# Altium schematic sheet Sheet1.SchDoc(Sheet1)
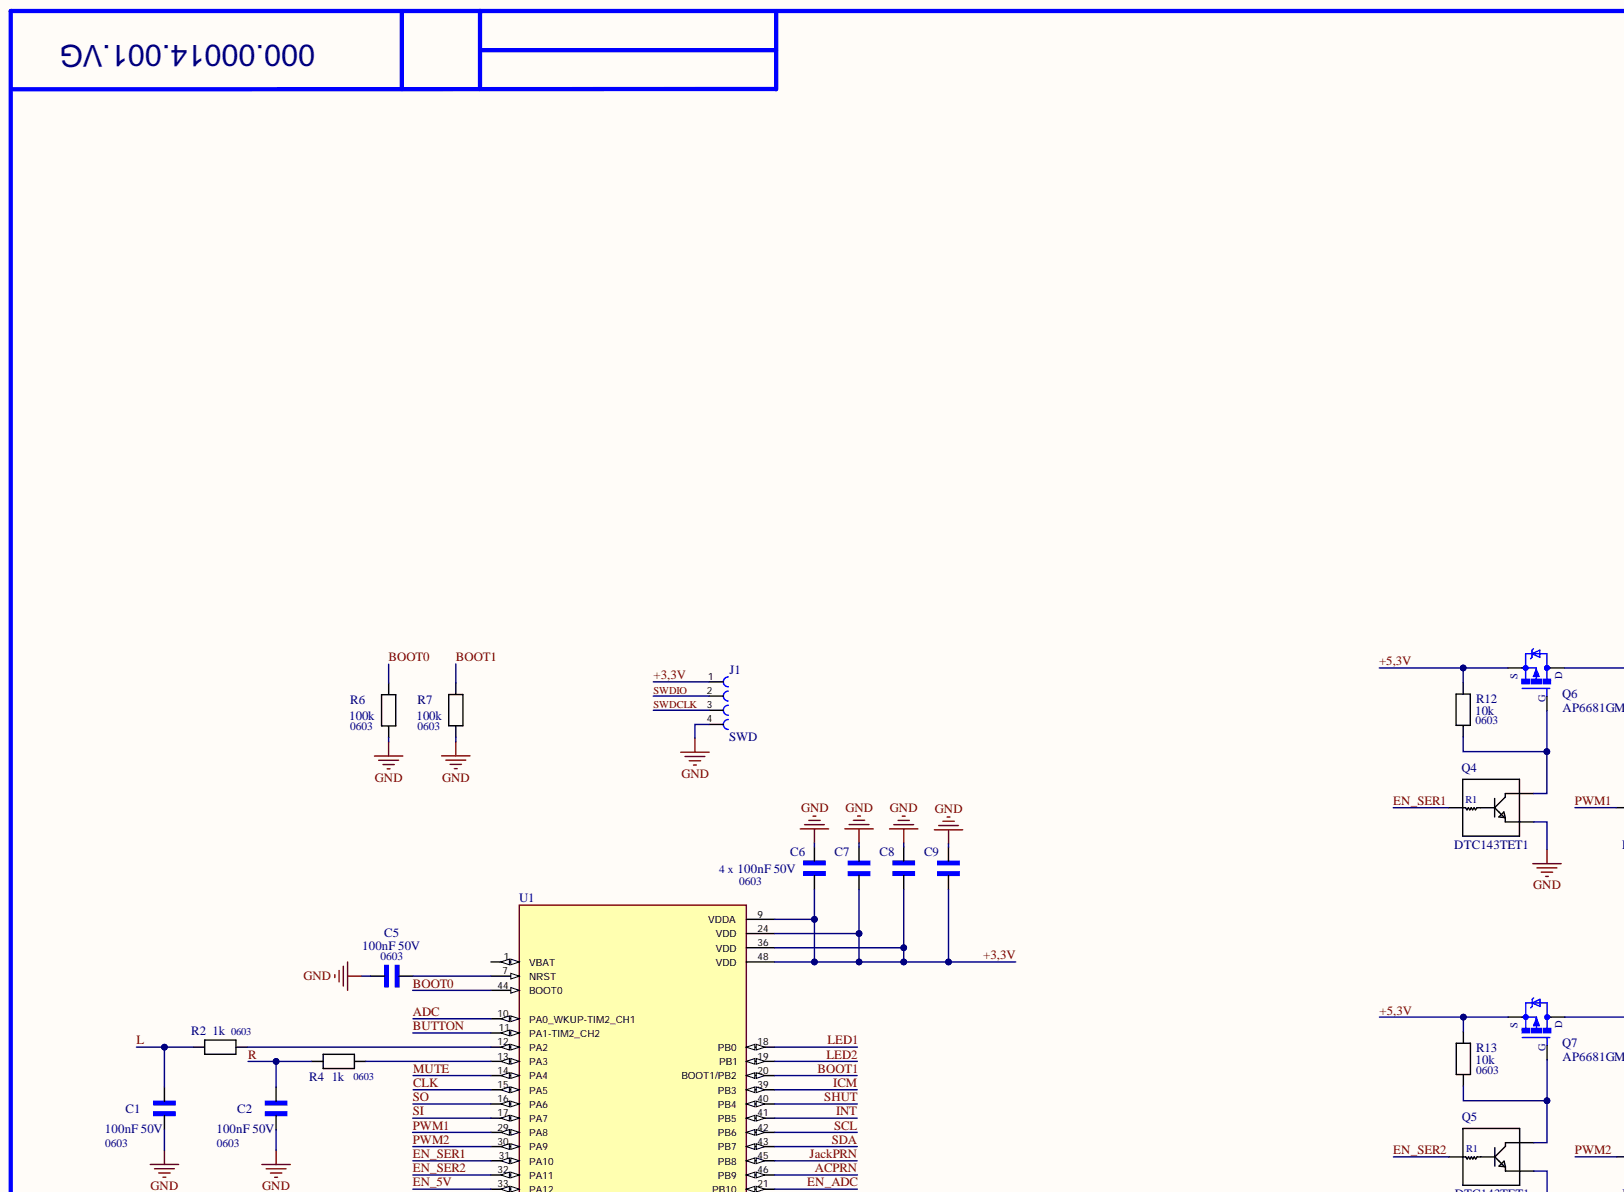
[diagram p0: Sheet1.SchDoc, top left]
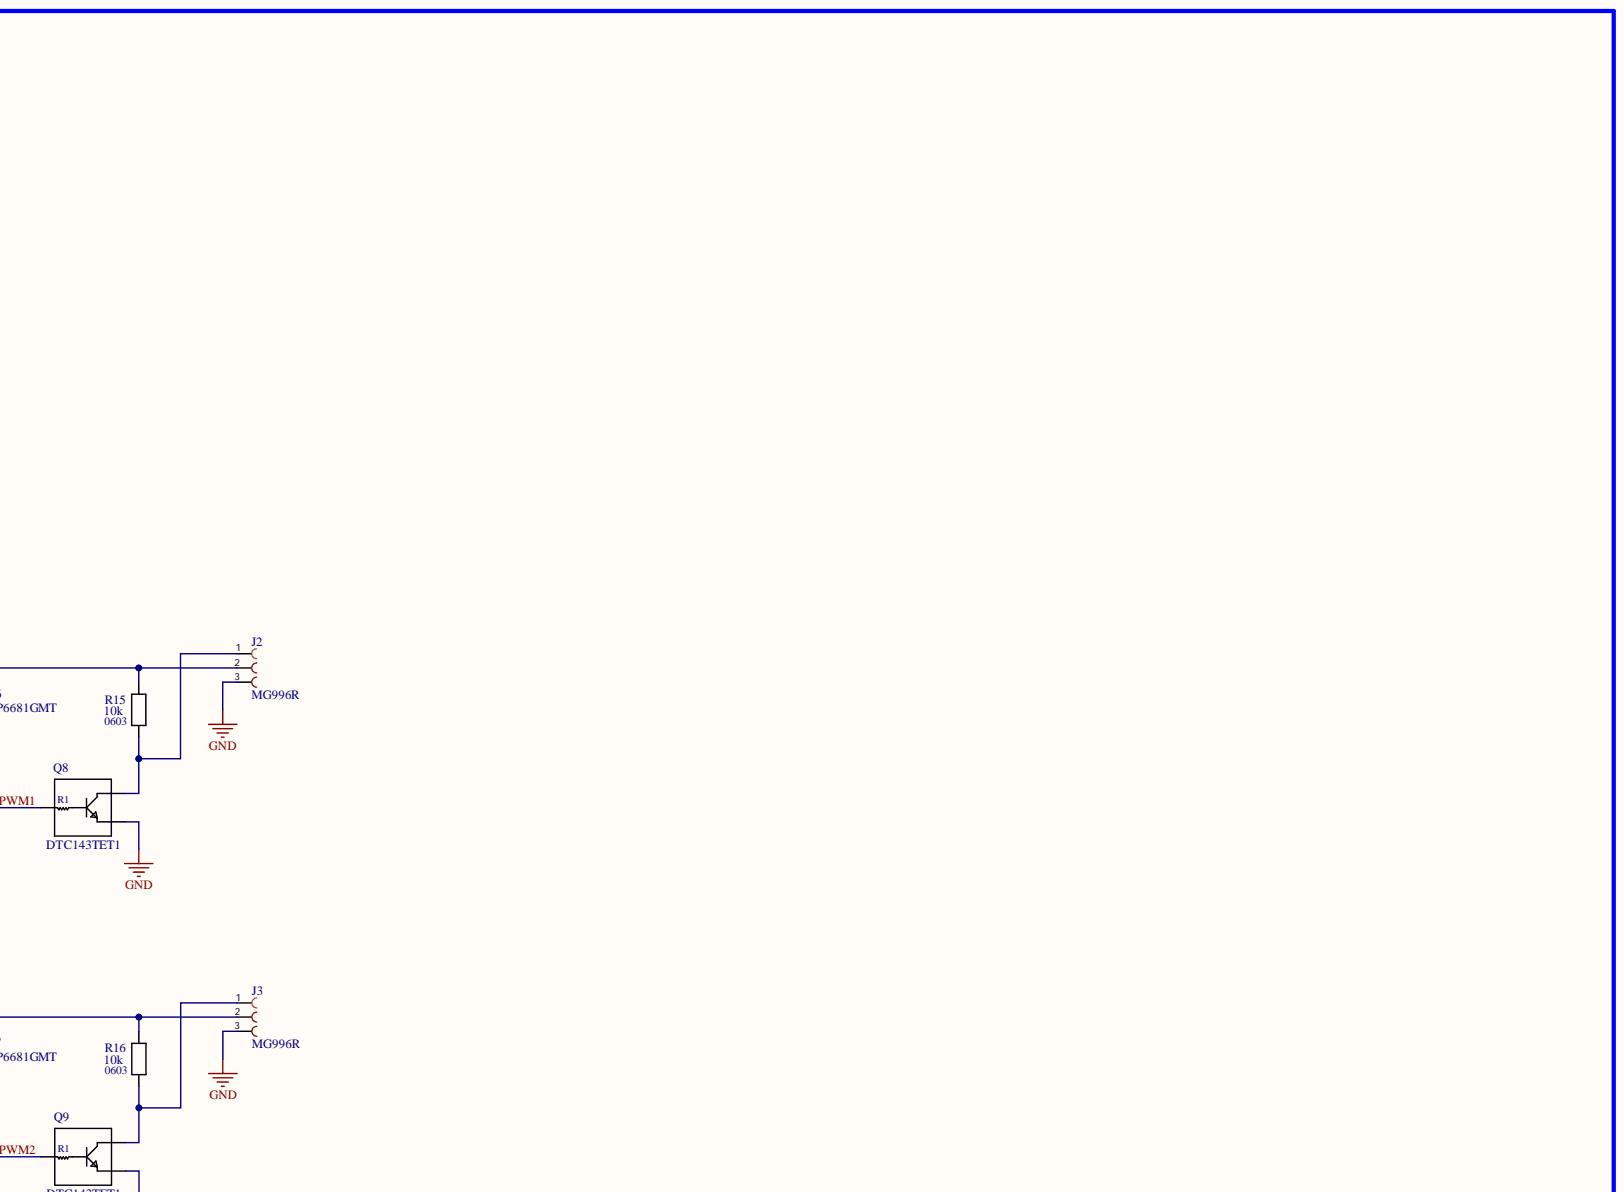
[diagram p1: Sheet1.SchDoc, top right]
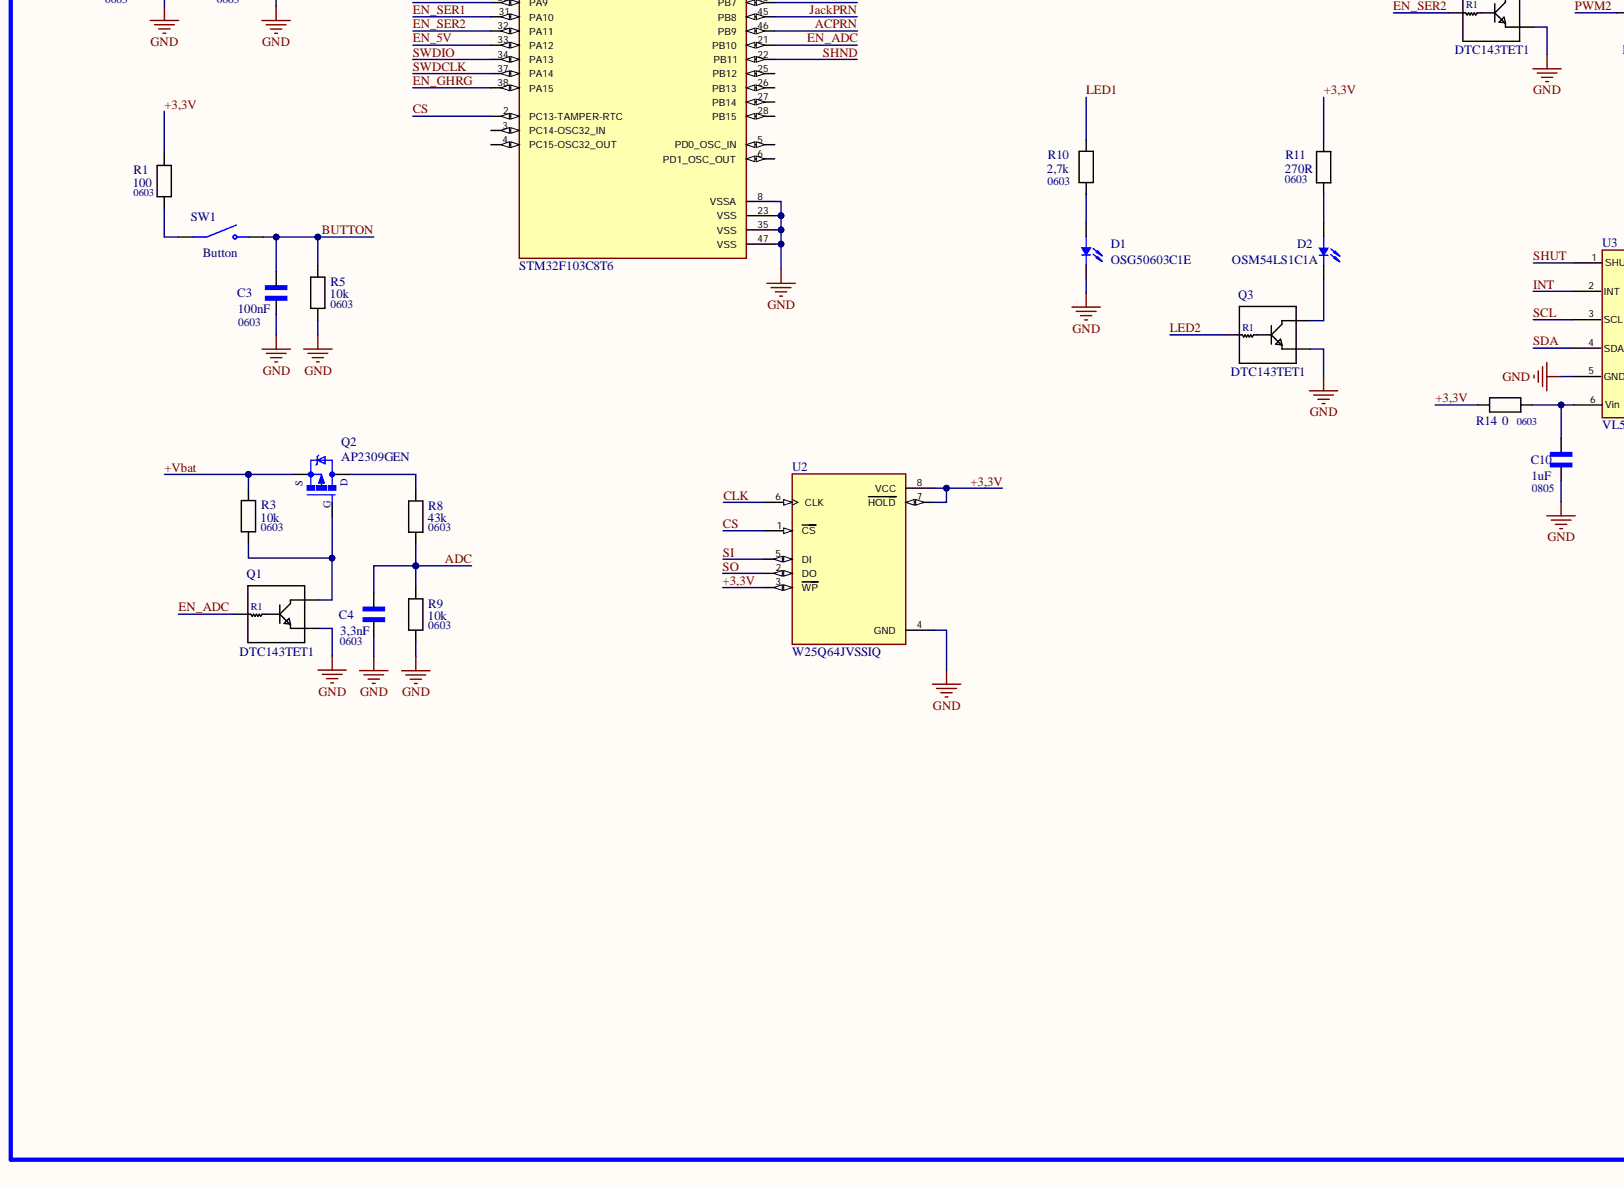
[diagram p2: Sheet1.SchDoc, bottom left]
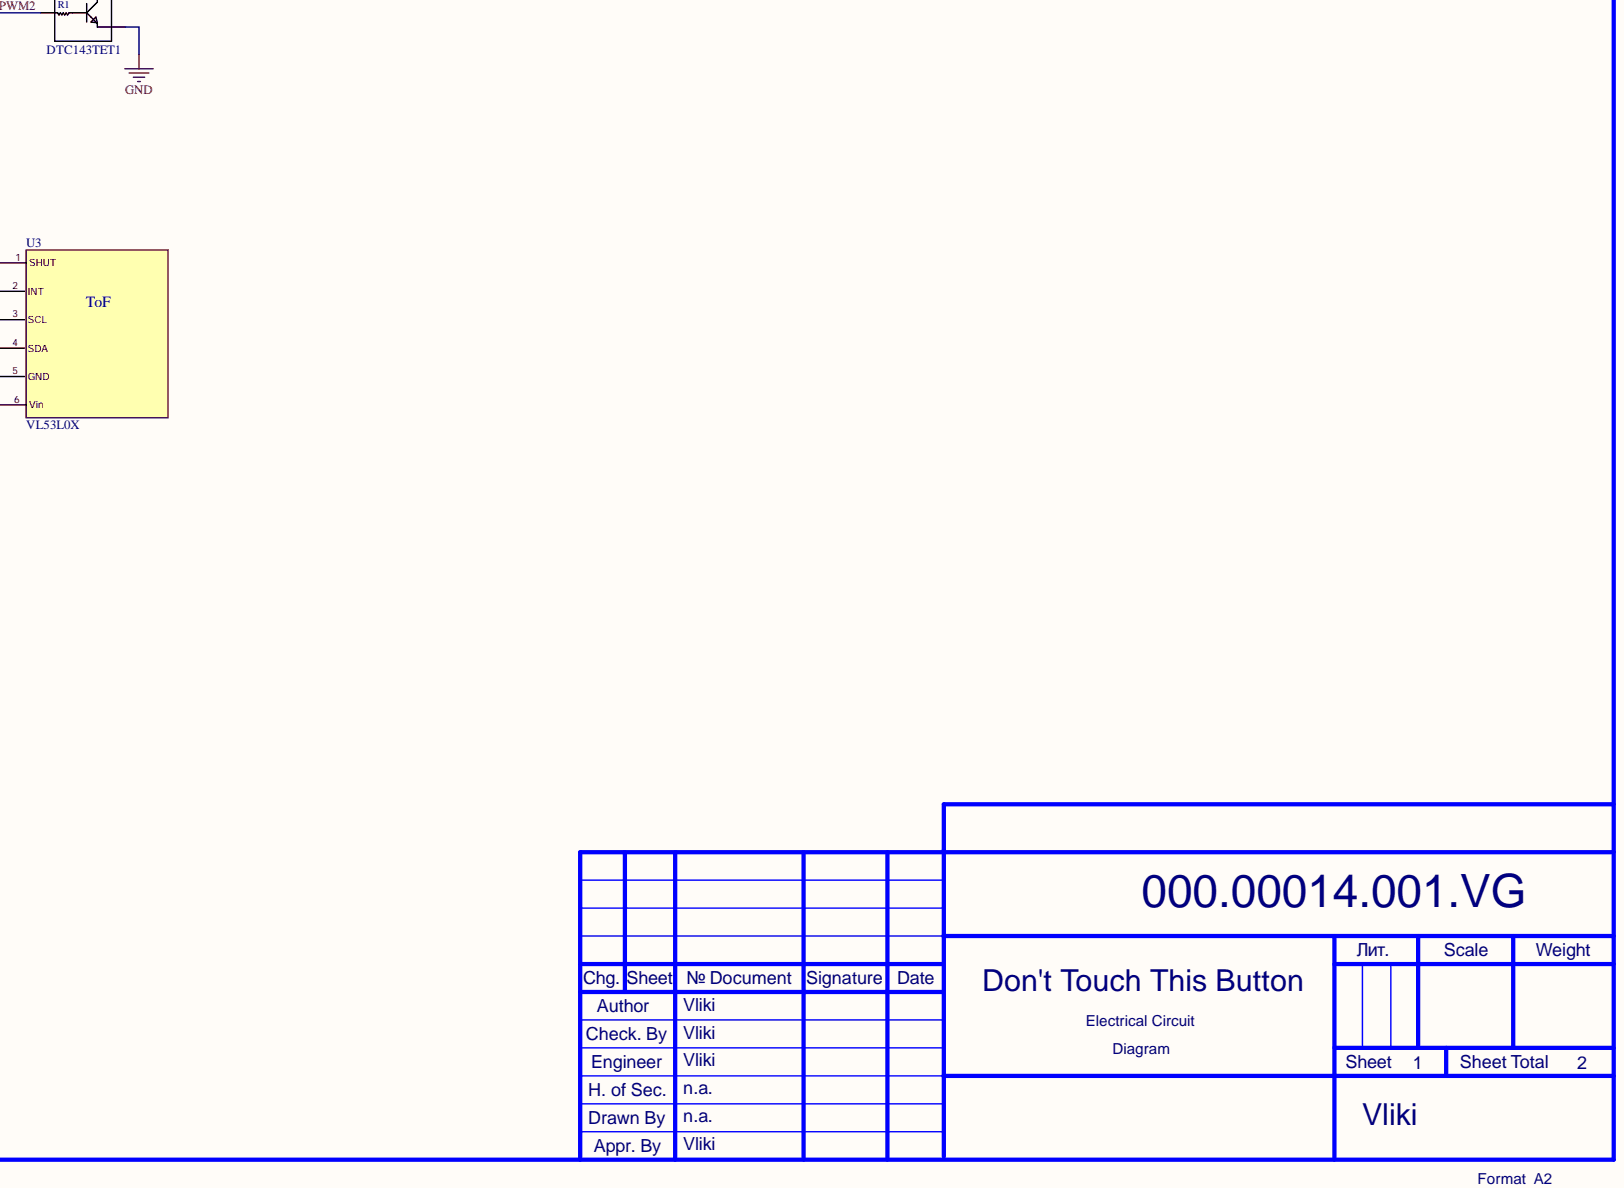
[diagram p3: Sheet1.SchDoc, bottom right]

## Parts
C1  100nF 50V  Case: 0603  [SMD 0603 CAP]
C2  100nF 50V  Case: 0603  [SMD 0603 CAP]
C3  100nF  Case: 0603  [SMD 0603 CAP]
C4  3,3nF  Case: 0603  [SMD 0603 CAP]
C5  100nF 50V  Case: 0603  [SMD 0603 CAP]
C6  100nF 50V  [SMD 0603 CAP]
C7  100nF 50V  [SMD 0603 CAP]
C8  100nF 50V  [SMD 0603 CAP]
C9  100nF 50V  [SMD 0603 CAP]
C10  1uF  Case: 0805  [SMD 0805 CAP]
D1  OSG50603C1E  [SMD 0805 LED-G]
D2  OSM54LS1C1A  [LED-3mm Y]
J1  SWD  [PN1X4]
J2  MG996R  [PN1X3]
J3  MG996R  [PN1X3]
Q1  DTC143TET1  [SC75]
Q2  AP2309GEN  [SOT-23-3]
Q3  DTC143TET1  [SC75]
Q4  DTC143TET1  [SC75]
Q5  DTC143TET1  [SC75]
Q6  AP6681GMT  [PMPAK 5x6]
Q7  AP6681GMT  [PMPAK 5x6]
Q8  DTC143TET1  [SC75]
Q9  DTC143TET1  [SC75]
R1  100  Case: 0603  [SMD 0603]
R2  1k  Case: 0603  [SMD 0603]
R3  10k  Case: 0603  [SMD 0603]
R4  1k  Case: 0603  [SMD 0603]
R5  10k  Case: 0603  [SMD 0603]
R6  100k  Case: 0603  [SMD 0603]
R7  100k  Case: 0603  [SMD 0603]
R8  43k  Case: 0603  [SMD 0603]
R9  10k  Case: 0603  [SMD 0603]
R10  2,7k  Case: 0603  [SMD 0603]
R11  270R  Case: 0603  [SMD 0603]
R12  10k  Case: 0603  [SMD 0603]
R13  10k  Case: 0603  [SMD 0603]
R14  0  Case: 0603  [SMD 0603]
R15  10k  Case: 0603  [SMD 0603]
R16  10k  Case: 0603  [SMD 0603]
SW1  Button  [PN1X2]
U1  STM32F103C8T6  [QFP50P900X900X160-48N]
U2  W25Q64JVSSIQ  [SO8 5,3mm]
U3  VL53L0X  [PN1X6]

## Nets
GND: C1.1 C2.1 C3.1 C4.1 C5.1 C6.2 C7.2 C8.2 C9.2 C10.1 D1.C J1.4 J2.3 J3.3 Q1.2 Q3.2 Q4.2 Q5.2 Q8.2 Q9.2 R5.1 R6.2 R7.2 R9.1 U1.8(VSSA) U1.23(VSS) U1.35(VSS) U1.47(VSS) U2.4(GND) U3.5(GND)
+3,3V: C6.1 C7.1 C8.1 C9.1 J1.1 R1.1 R11.1 R14.2 U1.9(VDDA) U1.24(VDD) U1.36(VDD) U1.48(VDD) U2.3(WP) U2.7(HOLD) U2.8(VCC)
+5,3V: Q6.2 Q7.2 R12.2 R13.2
+Vbat: Q2.2 R3.2
ACPRN: U1.46(PB9)
ADC: C4.2 R8.1 R9.2 U1.10(PA0_WKUP-TIM2_CH1)
BOOT0: R6.1 U1.44(BOOT0)
BOOT1: R7.1 U1.20(BOOT1/PB2)
BUTTON: C3.2 R5.2 SW1.2 U1.11(PA1-TIM2_CH2)
CLK: U1.15(PA5) U2.6(CLK)
CS: U1.2(PC13-TAMPER-RTC) U2.1(CS)
EN_5V: U1.33(PA12)
EN_ADC: Q1.1 U1.21(PB10)
EN_GHRG: U1.38(PA15)
EN_SER1: Q4.1 U1.31(PA10)
EN_SER2: Q5.1 U1.32(PA11)
ICM: U1.39(PB3)
INT: U1.41(PB5) U3.2(INT)
JackPRN: U1.45(PB8)
L: C1.2 R2.2
LED1: R10.2 U1.18(PB0)
LED2: Q3.1 U1.19(PB1)
MUTE: U1.14(PA4)
PWM1: Q8.1 U1.29(PA8)
PWM2: Q9.1 U1.30(PA9)
R: C2.2 R4.2
SCL: U1.42(PB6) U3.3(SCL)
SDA: U1.43(PB7) U3.4(SDA)
SHND: U1.22(PB11)
SHUT: U1.40(PB4) U3.1(SHUT)
SI: U1.17(PA7) U2.5(DI)
SO: U1.16(PA6) U2.2(DO)
SWDCLK: J1.3 U1.37(PA14)
SWDIO: J1.2 U1.34(PA13)
NetC5_2: C5.2 U1.7(NRST)
NetC10_2: C10.2 R14.1 U3.6(Vin)
NetD1_A: D1.A R10.1
NetD2_A: D2.A R11.2
NetD2_C: D2.C Q3.3
NetJ2_1: J2.1 Q8.3 R15.1
NetJ2_2: J2.2 Q6.3 R15.2
NetJ3_1: J3.1 Q9.3 R16.1
NetJ3_2: J3.2 Q7.3 R16.2
NetQ1_3: Q1.3 Q2.1 R3.1
NetQ2_3: Q2.3 R8.2
NetQ4_3: Q4.3 Q6.1 R12.1
NetQ5_3: Q5.3 Q7.1 R13.1
NetR1_2: R1.2 SW1.1
NetR2_1: R2.1 U1.12(PA2)
NetR4_1: R4.1 U1.13(PA3)

Not connected: U1 1(VBAT) 3(PC14-OSC32_IN) 4(PC15-OSC32_OUT) 5(PD0_OSC_IN) 6(PD1_OSC_OUT) 25(PB12) 26(PB13) 27(PB14) 28(PB15)

## Library data of the parts
C1 C2 C5 C7-C9 = CL32B106KLJNNNE: 100nF 50V X7R +-10%; Manufacturer: SAMSUNG ELECTRO-MECHANICS; Component Kind: Standard; HelpURL: https://store.comet.bg/Catalogue/Product/36844/; Library Name: CAN_Library.IntLib; Model: CL32B106KLJNNNE; Value: 100nF 50V
  C7-C9: Case: 0603
C3 = CL32B106KLJNNNE: 100nF 50V X7R +-10%; Manufacturer: SAMSUNG ELECTRO-MECHANICS; Model: CL10B104KB8NNNC; Component Kind: Standard; HelpURL: https://store.comet.bg/Catalogue/Product/5963/; Library Name: CAN_Library.IntLib; Value: 100nF 50V
C4 = CL32B106KLJNNNE: 2.2uF 16V X7R +-10%; Manufacturer: SAMSUNG ELECTRO-MECHANICS; Model: CL10B332KB8NNNC; Value: 3,3nF 50V; Component Kind: Standard; HelpURL: https://store.comet.bg/Catalogue/Product/5613/; Library Name: CAN_Library.IntLib
C6 = CL32B106KLJNNNE: 100nF 50V X7R +-10%; Manufacturer: SAMSUNG ELECTRO-MECHANICS; Text: 4 x; Case: 0603; Component Kind: Standard; HelpURL: https://store.comet.bg/Catalogue/Product/36844/; Library Name: CAN_Library.IntLib; Model: CL32B106KLJNNNE; Value: 100nF 50V
C10 = CL32B106KLJNNNE: 1.0uF 50V X7R +-10%; Manufacturer: SAMSUNG ELECTRO-MECHANICS; Model: CL21B105KBFNNNE; Value: 1uF 50V; Component Kind: Standard; HelpURL: https://store.comet.bg/Catalogue/Product/9031/; Library Name: CAN_Library.IntLib
D1 = KP-2012: 1.6x0.8mm (0603), 120-220mcd/5mA, Pure Green; MF: KINGBRIGHT; Case: 0805 Rohm Semiconductor; Component Kind: Standard; Library Name: CAN_Library.IntLib; URL: https://store.comet.bg/Catalogue/Product/49228/; Value: OSG50603C1E
D2 = KP-2012: 3.5x2.8mm Warm White 3000K 2500mcd 6lm 120deg; MF: OPTOSUPPLY INTERNATIONAL; Case: 3.2x2.8mm; Component Kind: Standard; Library Name: CAN_Library.IntLib; Value: OSM54LS1C1A
J1 = PN1X4: Single Row / Straight / Without Shroud Female header Female 4 @ Pin header, socket _Si...; Value: PN1X4; Case: 0,8 mm; Component Kind: Standard; Library Name: CAN_Library.IntLib
J2 J3 = MG996R: Servo 4.8V - 7.2V, 0.9 - 2.5A, 0 ºC – 55 ºC, 55g, PWM 20mS; Case: PN1X3; Component Kind: Standard; Library Name: CAN_Library.IntLib; Value: MG996R
Q1 Q3-Q5 Q8 Q9 = DTC143TET1: NPN Digital 50V 100mA 200mW  R1=4,7kOm; Manufacturer: ROHM Semiconductor; Case: SC75; Component Kind: Standard; HalpURL: https://store.comet.bg/Catalogue/Product/5040902/; Library Name: CAN_Library.IntLib; Value: DTC143TET1
Q2 = AP2309GEN: PMOS 30V 4.2A 1.38W 52mOhm/10V; 80mOhm/4.5V; MF: ADVANCED POWER ELECTR. CO; MP: AP2309GEN-HF-3TR; Case: SOT-23-3; Component Kind: Standard; Library Name: CAN_Library.IntLib; URL: https://store.comet.bg/Catalogue/Product/49685/; Value: AP2309GEN
Q6 Q7 = AP2309GEN: PMOS 30V 135A 83.3W 3.1mOhm/10V; 4.6mOhm/4.5V; MF: ADVANCED POWER ELECTR. CO; Case: PMPAK 5X6; Component Kind: Standard; Library Name: CAN_Library.IntLib; URL: https://store.comet.bg/Catalogue/Product/18327/; Value: AP6681GMT
R1 = Resistor_2: RES SMD 0603 5% 200ppm 100R 1/10W; Component Kind: Standard; HelpURL: https://store.comet.bg/Catalogue/Product/3448/; Library Name: CAN_Library.IntLib; Value: 100
R2 R4 = Resistor_2: Описание: RES SMD 0603 5% 200ppm 1.0K 1/10W; Component Kind: Standard; HelpURL: https://store.comet.bg/Catalogue/Product/3458/; Library Name: CAN_Library.IntLib; Value: 1k
R3 R5 R9 R12 R13 R15 R16 = Resistor_2: RES SMD 0603 5% 200ppm 47K 1/4W; Component Kind: Standard; HelpURL: https://store.comet.bg/Catalogue/Product/29639/; Library Name: CAN_Library.IntLib; Value: 10k
R6 R7 R10 R11 = Resistor_2: RES; Component Kind: Standard; HelpURL: https://store.comet.bg/Catalogue/RES/Resistors/#eyJpcHAiOiIxMDAiLCJtIjoiIn0%3D; Library Name: CAN_Library.IntLib
  R6 R7: Value: 100k
  R10: Value: 2,7k
  R11: Value: 270R
R8 = Resistor_2: RES SMD 0603 5% 200ppm 43K 1/10W; Component Kind: Standard; HelpURL: https://store.comet.bg/Catalogue/Product/4841/; Library Name: CAN_Library.IntLib; Value: 43k
R14 = Resistor_2: RES SMD 0603 JUMPER MAX 50mOhm; Component Kind: Standard; HelpURL: https://store.comet.bg/Catalogue/Product/4437/; Library Name: CAN_Library.IntLib; Value: 0
SW1 = Switch: Switch 2pin SPDT ON-ON 21x15mm 6A/250VAC; Value: MRS-101A; Component Kind: Standard; Library Name: CAN_Library.IntLib; Package: None
U1 = STM32F103C8T6: ARMÂ® CortexÂ®-M3 STM32F1 Microcontroller IC 32-Bit 72MHz 64KB (64K x 8) FLASH 48-LQFP (7x7); MF: STMicroelectronics; Availability: In Stock; Check_prices: https://www.snapeda.com/parts/STM32F103C8T6/STMicroelectronics/view-part/?ref=eda; Component Kind: Standard; Library Name: CAN_Library.IntLib; MP: STM32F103C8T6; Package: LQFP-48 STMicroelectronics; Price: None; Purchase-URL: https://pricing.snapeda.com/search/part/STM32F103C8T6/?ref=eda; SnapEDA_Link: https://www.snapeda.com/parts/STM32F103C8T6/STMicroelectronics/view-part/?ref=snap; Value: STM32F103C8T6
U2 = W25Q64JVSSIQ: 64Mbit (8Mx8) FLASH mem. SPI 2.7-3.6V SO8 5.3mm; MF: Winbond Electronics; Component Kind: Standard; Library Name: CAN_Library.IntLib; MP: W25Q64JVSSIQ; Package: SOIC-8 Winbond; URL: https://store.comet.bg/Catalogue/Product/50338/
U3 = VL53L0X: Time-of-Flight (ToF) laser-ranging module; MF: STMicroelectronics; Case: PN1X6; Component Kind: Standard; Library Name: CAN_Library.IntLib; URL: https://www.st.com/en/imaging-and-photonics-solutions/vl53l0x.html; Value: VL53L0X
